# Altium schematic sheet Thermal_Management.SchDoc
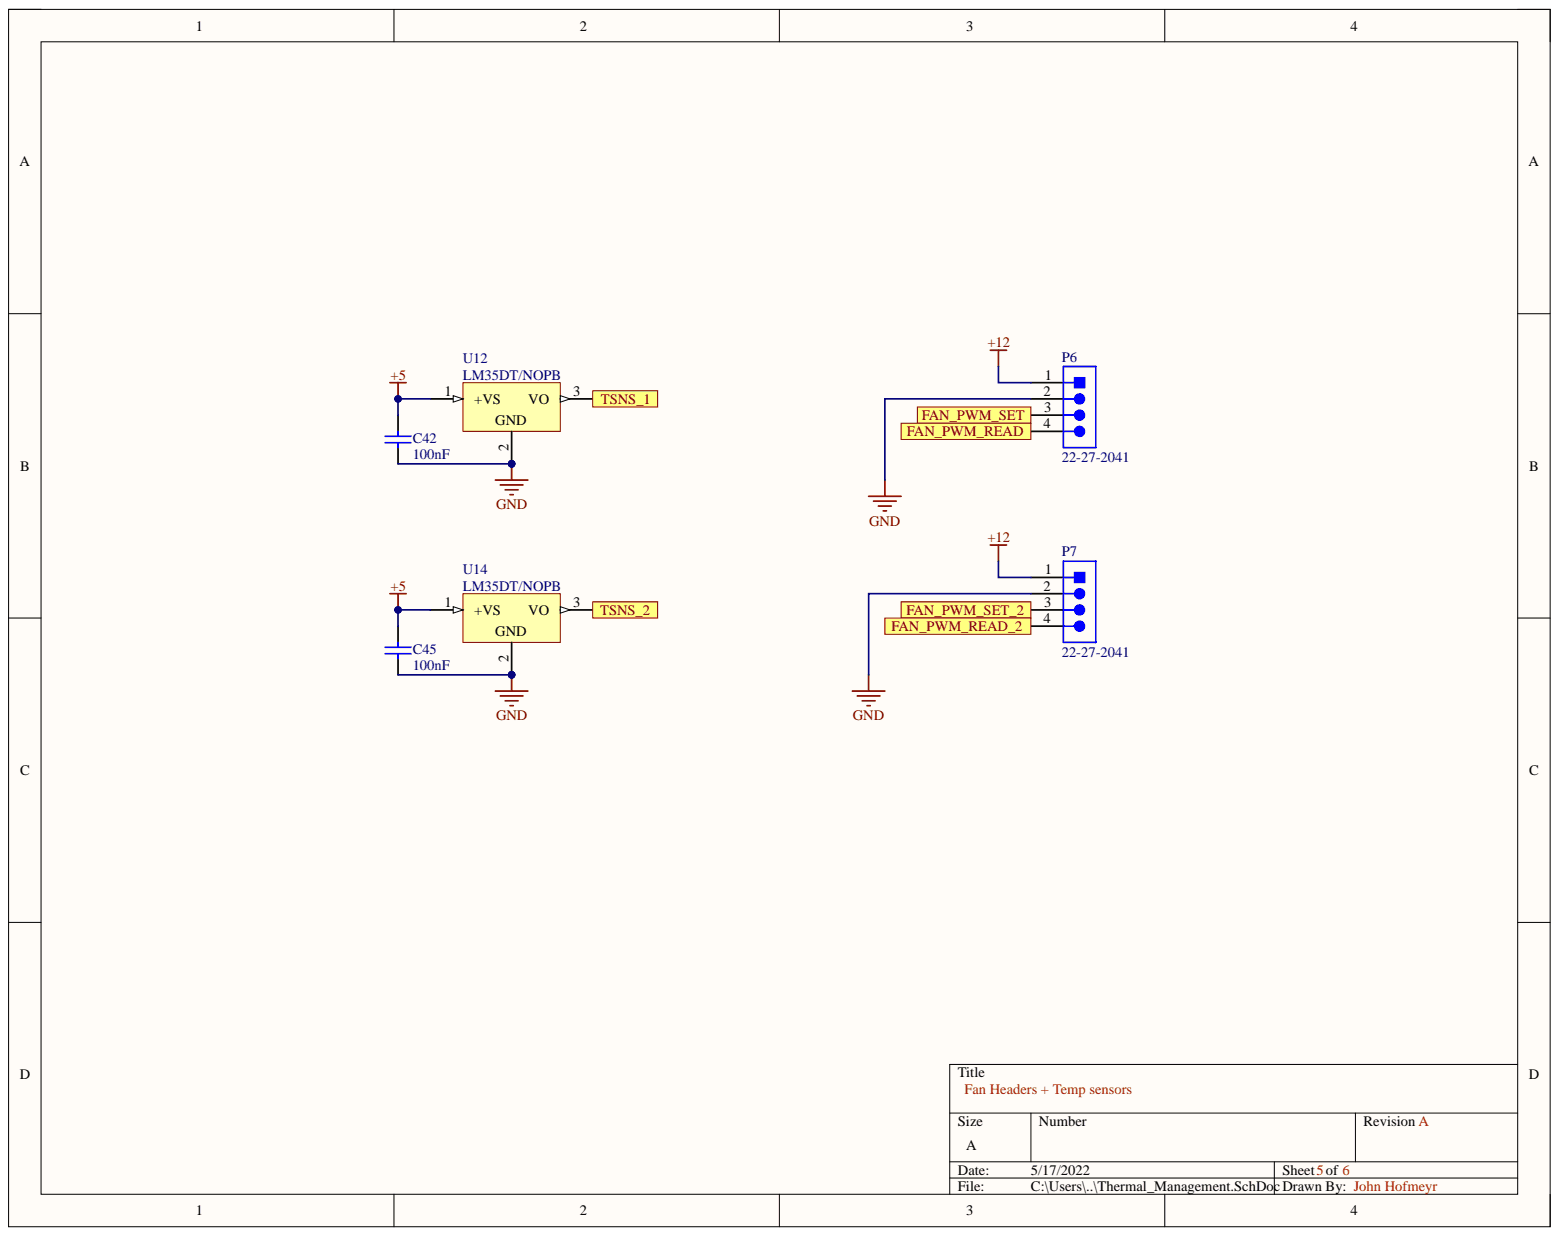
[diagram p0: Thermal_Management.SchDoc]

## Parts
C42  100nF  [CAPC2013X140X50ML20T25]
C45  100nF  [CAPC2013X140X50ML20T25]
P6  22-27-2041  [MOLX-22-27-2041_V]
P7  22-27-2041  [MOLX-22-27-2041_V]
U12  LM35DT/NOPB  [NEB0003A]
U14  LM35DT/NOPB  [NEB0003A]

## Ports
FAN_PWM_READ, FAN_PWM_READ_2, FAN_PWM_SET, FAN_PWM_SET_2, TSNS_1, TSNS_2

## Nets
+5: C42.2 C45.2 U12.1 U14.1
+12: P6.1 P7.1
GND: C42.1 C45.1 P6.2 P7.2 U12.2 U14.2
FAN_PWM_READ: P6.4 ; port FAN_PWM_READ
FAN_PWM_READ_2: P7.4 ; port FAN_PWM_READ_2
FAN_PWM_SET: P6.3 ; port FAN_PWM_SET
FAN_PWM_SET_2: P7.3 ; port FAN_PWM_SET_2
TSNS_1: U12.3 ; port TSNS_1
TSNS_2: U14.3 ; port TSNS_2

## Library data of the parts
C42 C45 = CMP-2007-04689-1: Manufacturer: AVX Interconnect / Elco; Part Number: 08055D104KAT2A; Component Kind: Standard; Library Name: Altium Content Vault
P6 P7 = CMP-2000-05243-1: Male Header, Pitch 2.54 mm, 1 x 4 Position, Height 11.7 mm, Tail Length 3.56 mm, RoHS, Bulk; Manufacturer: Molex; Current rating: 4A; Voltage rating: 250V; Component Kind: Standard; Contact material: Tinplatedbrass; Datasheet URL: http://www.molex.com/pdm_docs/sd/022272041_sd.pdf; Datasheet Version: Rev. F, 06/2009; Housing material: Nylon(UL94V-0); Library Name: Altium Content Vault; Manufacturer URL: http://www.molex.com/; Operating temperature: 0degCto+75degC
U12 U14 = CMP-0066-00346-3: Precision Centigrade Temperature Sensor, 3-pin TO-220, Pb-Free; Manufacturer: Texas Instruments; CompType: Temp Sensor IC; PackageTopMarking: NSUZXYTTE#*LM35DT; PowerWise: N; Code_JEDEC: TO-220-AB; Component Kind: Standard; ComponentLink1Description: Datasheet URL; ComponentLink1URL: http://www.ti.com/general/docs/lit/getliterature.tsp?genericPartNumber=LM35&fileType=pdf; Library Name: Altium Content Vault; PackageDescription: TO-220, Molded, 3 Lead, Auto L/F; PackageReference: NEB0003A; PinCount: 3; RoHS: Y
